# Altium schematic sheet Driver_BLDC.SchDoc
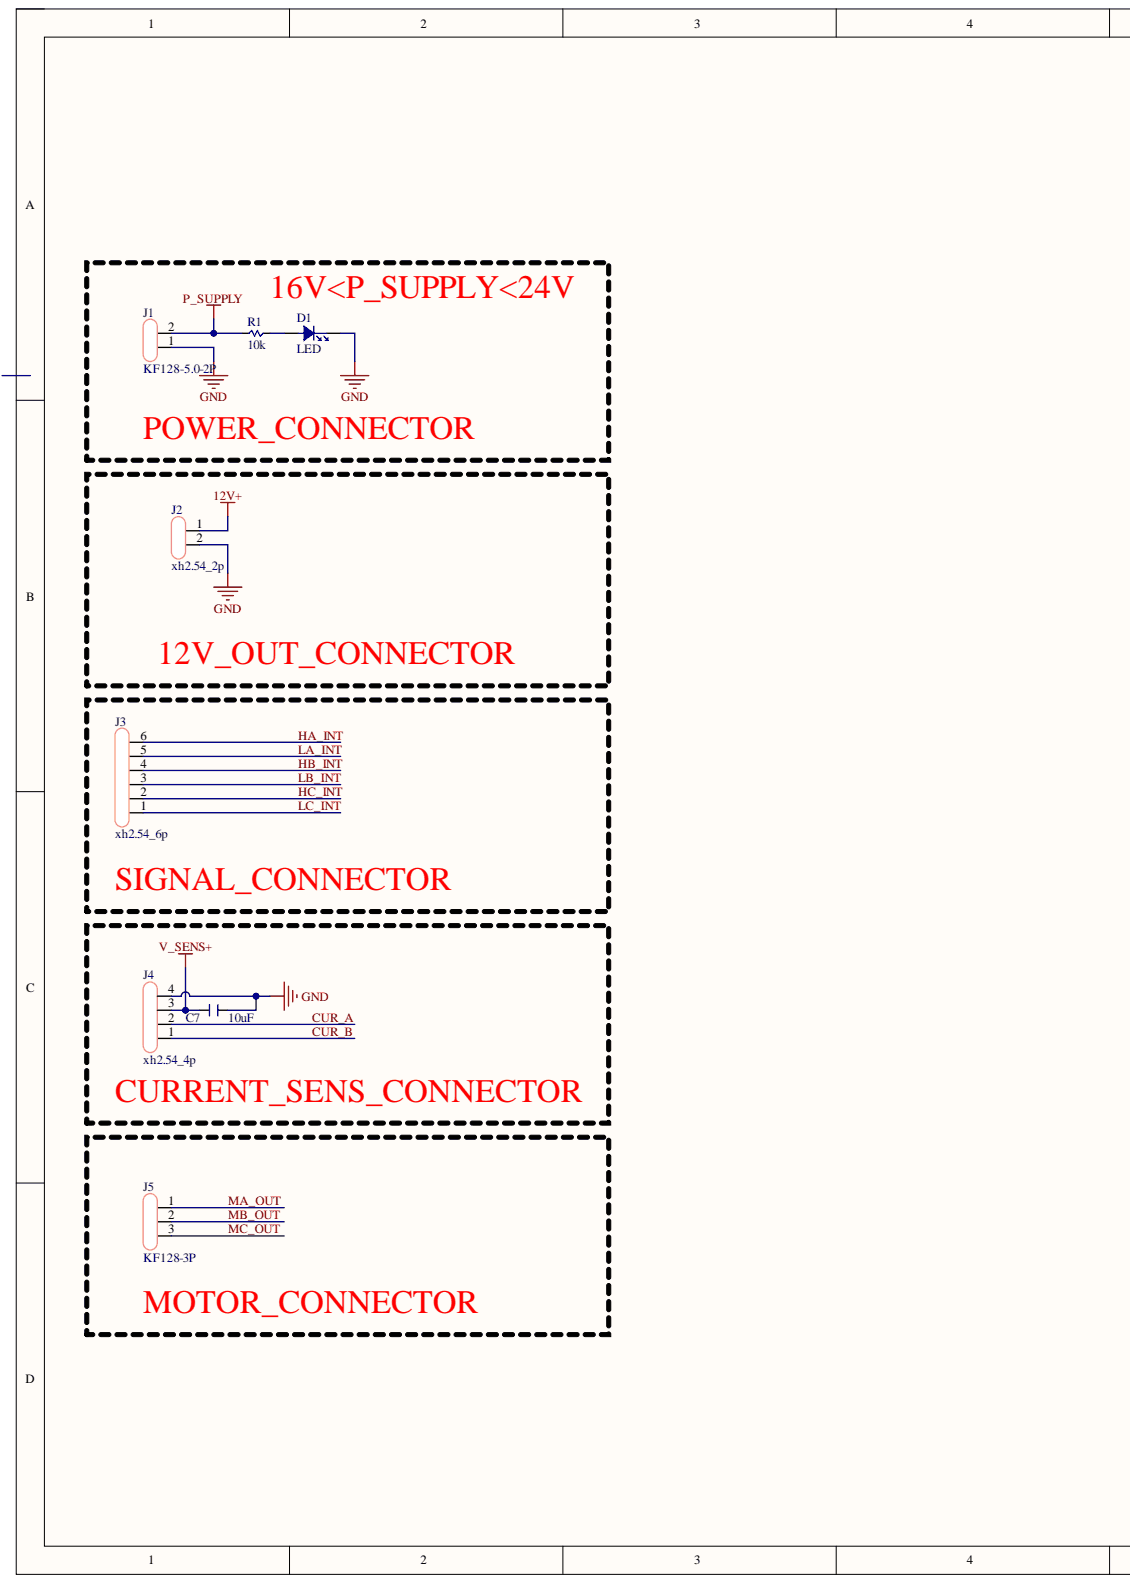
[diagram p0: Driver_BLDC.SchDoc, left]
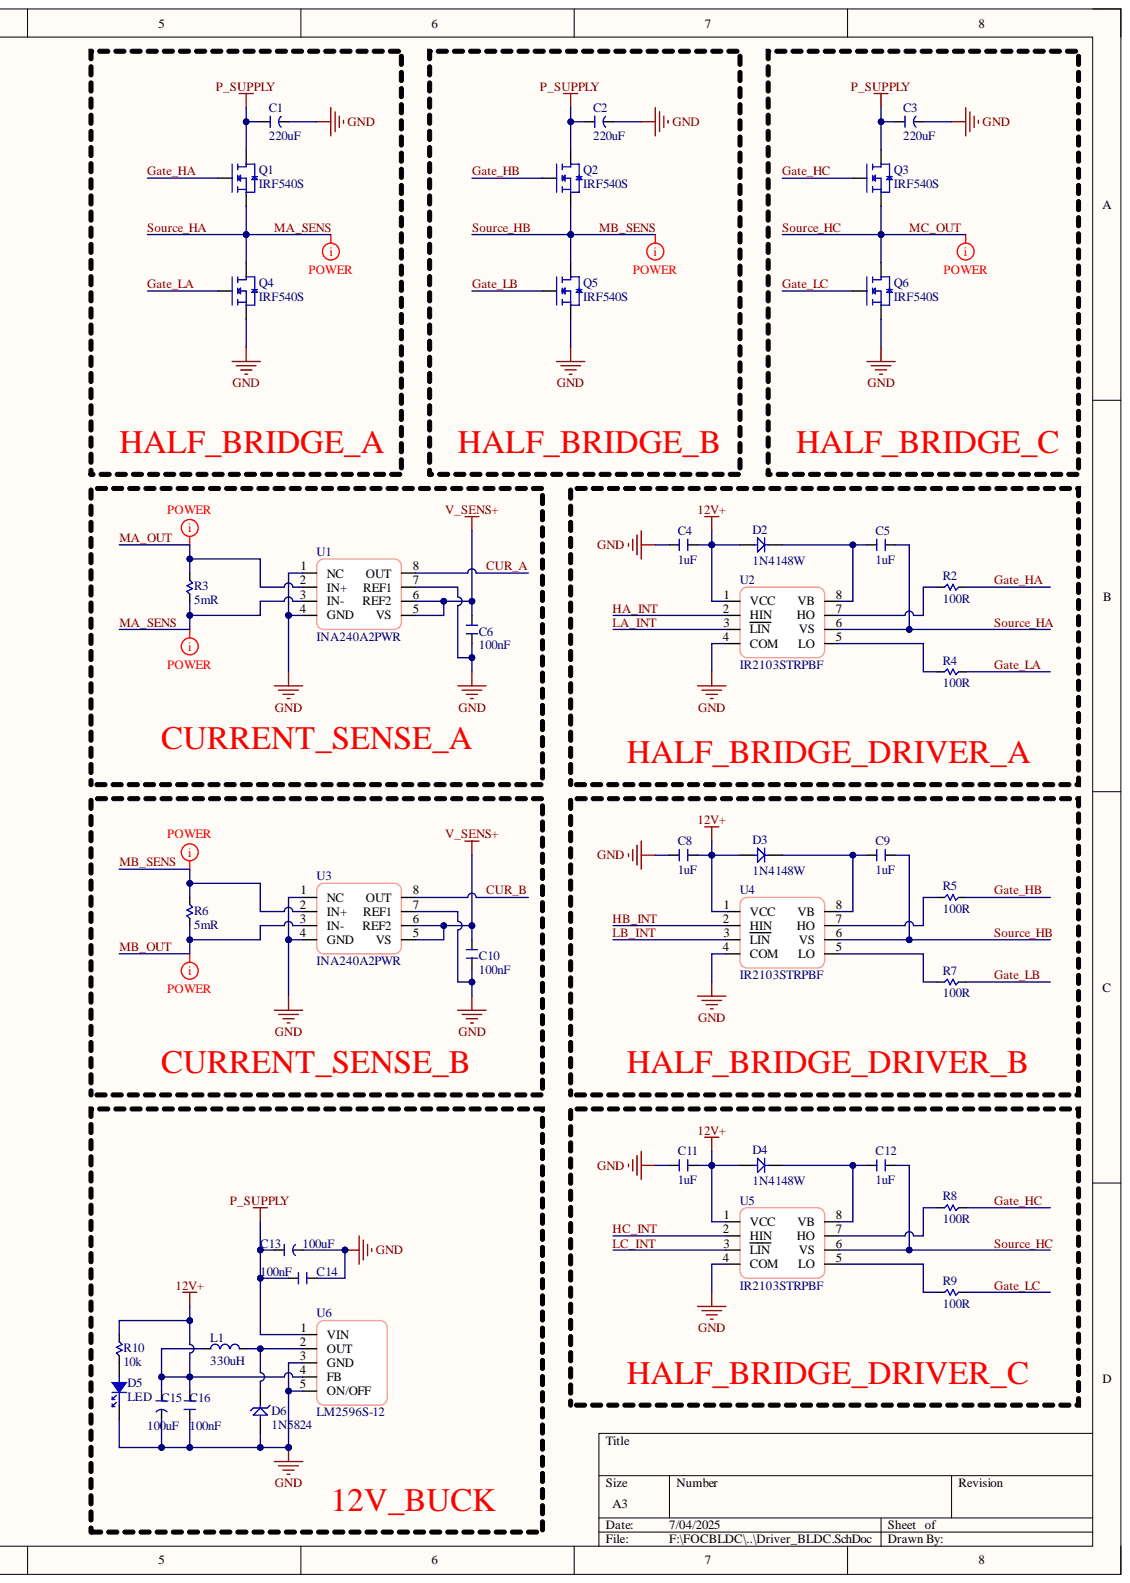
[diagram p1: Driver_BLDC.SchDoc, right]

## Parts
C1  220uF  [CAPAE9583X105M]
C2  220uF  [CAPAE9583X105M]
C3  220uF  [CAPAE9583X105M]
C4  1uF  [CAPC3216X18M]
C5  1uF  [CAPC3216X18M]
C6  100nF  [CAPC3216X18M]
C7  10uF  [CAPC3216X18M]
C8  1uF  [CAPC3216X18M]
C9  1uF  [CAPC3216X18M]
C10  100nF  [CAPC3216X18M]
C11  1uF  [CAPC3216X18M]
C12  1uF  [CAPC3216X18M]
C13  100uF  [CAPAE7466X77M]
C14  100nF  [CAPC3216X18M]
C15  100uF  [CAPAE7466X77M]
C16  100nF  [CAPC3216X18M]
D1  LED  [LEDC3216X120N]
D2  1N4148W  [SODFL370X135-2M]
D3  1N4148W  [SODFL370X135-2M]
D4  1N4148W  [SODFL370X135-2M]
D5  LED  [LEDC3216X120N]
D6  1N5824  [DIOM5025X22M]
J1  KF128-5.0-2P  [KF128-2P]
J2  xh2.54_2p  [xh2.54_2p]
J3  xh2.54_6p  [xh2.54_6p]
J4  xh2.54_4p  [xh2.54_4P]
J5  KF128-3P  [KF128-3P]
L1  330uH  [INDP120120X80M]
Q1  IRF540S  [DPAK254P1524X483-3M]
Q2  IRF540S  [DPAK254P1524X483-3M]
Q3  IRF540S  [DPAK254P1524X483-3M]
Q4  IRF540S  [DPAK254P1524X483-3M]
Q5  IRF540S  [DPAK254P1524X483-3M]
Q6  IRF540S  [DPAK254P1524X483-3M]
R1  10k  [RESC3116X06M]
R2  100R  [RESC3116X06M]
R3  5mR  [RESC6432X07M]
R4  100R  [RESC3116X06M]
R5  100R  [RESC3116X06M]
R6  5mR  [RESC6432X07M]
R7  100R  [RESC3116X06M]
R8  100R  [RESC3116X06M]
R9  100R  [RESC3116X06M]
R10  10k  [RESC3116X06M]
U1  INA240A2PWR  [TSOP65P640X120-8M]
U2  IR2103STRPBF  [SOIC127P600X175-8M]
U3  INA240A2PWR  [TSOP65P640X120-8M]
U4  IR2103STRPBF  [SOIC127P600X175-8M]
U5  IR2103STRPBF  [SOIC127P600X175-8M]
U6  LM2596S-12  [DPAK170P1435X470-6M]

## Nets
12V+: C4.1 C8.1 C11.1 C15.P C16.1 D2.A D3.A D4.A J2.1 L1.1 R10.1 U2.1(VCC) U4.1(VCC) U5.1(VCC) U6.4(FB)
GND: C1.N C2.N C3.N C4.2 C6.1 C7.2 C8.2 C10.1 C11.2 C13.N C14.2 C15.N C16.2 D1.K D5.K D6.A J1.1 J2.2 J4.4 Q4.S Q5.S Q6.S U1.1(NC) U1.4(GND) U1.7(REF1) U2.4(COM) U3.1(NC) U3.4(GND) U3.7(REF1) U4.4(COM) U5.4(COM) U6.3(GND) U6.5(ON/OFF)
P_SUPPLY: C1.P C2.P C3.P C13.P C14.1 J1.2 Q1.D Q2.D Q3.D R1.1 U6.1(VIN)
V_SENS+: C6.2 C7.1 C10.2 J4.3 U1.5(VS) U1.6(REF2) U3.5(VS) U3.6(REF2)
CUR_A: J4.2 U1.8(OUT)
CUR_B: J4.1 U3.8(OUT)
Gate_HA: Q1.G R2.2
Gate_HB: Q2.G R5.2
Gate_HC: Q3.G R8.2
Gate_LA: Q4.G R4.2
Gate_LB: Q5.G R7.2
Gate_LC: Q6.G R9.2
HA_INT: J3.6 U2.2(HIN)
HB_INT: J3.4 U4.2(HIN)
HC_INT: J3.2 U5.2(HIN)
LA_INT: J3.5 U2.3(LIN)
LB_INT: J3.3 U4.3(LIN)
LC_INT: J3.1 U5.3(LIN)
MA_OUT: J5.1 R3.2 U1.2(IN+)
MA_SENS: C5.1 Q1.S Q4.D R3.1 U1.3(IN-) U2.6(VS)
MB_OUT: J5.2 R6.1 U3.3(IN-)
MB_SENS: C9.1 Q2.S Q5.D R6.2 U3.2(IN+) U4.6(VS)
MC_OUT: C12.1 J5.3 Q3.S Q6.D U5.6(VS)
NetC5_2: C5.2 D2.K U2.8(VB)
NetC9_2: C9.2 D3.K U4.8(VB)
NetC12_2: C12.2 D4.K U5.8(VB)
NetD1_A: D1.A R1.2
NetD5_A: D5.A R10.2
NetD6_K: D6.K L1.2 U6.2(OUT)
NetR2_1: R2.1 U2.7(HO)
NetR4_1: R4.1 U2.5(LO)
NetR5_1: R5.1 U4.7(HO)
NetR7_1: R7.1 U4.5(LO)
NetR8_1: R8.1 U5.7(HO)
NetR9_1: R9.1 U5.5(LO)

## Library data of the parts
C1-C3 = VT1V221M-CRF10: 220uF 35V ±20% SMD,D8xL10.5mm Aluminum Electrolytic Capacitors - SMD ROHS; Component Kind: Standard; LCSC Part: C305376; Library Name: Driver_BLDC.SchLib
C4 C5 C8 C9 C11 C12 = CC1206JKX7R9BB105: 50V 1uF X7R ±5% 1206 Multilayer Ceramic Capacitors MLCC - SMD/SMT ROHS; Component Kind: Standard; LCSC Part: C527273; Library Name: Driver_BLDC.SchLib
C6 C10 C14 C16 = CC1206JRX7R9BB104: 50V 100nF X7R ±5% 1206 Multilayer Ceramic Capacitors MLCC - SMD/SMT ROHS; Component Kind: Standard; LCSC Part: C541547; Library Name: Driver_BLDC.SchLib
C7 = CC1206KKX7R8BB106: 25V 10uF X7R ±10% 1206 Multilayer Ceramic Capacitors MLCC - SMD/SMT ROHS; Component Kind: Standard; LCSC Part: C70462; Library Name: Driver_BLDC.SchLib
C13 C15 = VT1V101M-CRE77: 100uF 35V ±20% SMD,D6.3xL7.7mm Aluminum Electrolytic Capacitors - SMD ROHS; Component Kind: Standard; LCSC Part: C294520; Library Name: Driver_BLDC.SchLib
D1 D5 = LED_1206: SMD LED 1206; Available: no; Component Kind: Standard; Library Name: Driver_BLDC.SchLib
D2-D4 = 1N4148W: 75V Independent Type 1.25V@150mA 8ns 150mA SOD-123 Switching Diodes ROHS; C908248: C908248; Component Kind: Standard; Library Name: Driver_BLDC.SchLib
D6 = SS54: 40V Independent Type 550mV@5A 5A SMA(DO-214AC) Schottky Diodes ROHS; Component Kind: Standard; LCSC Part: C727065; Library Name: Driver_BLDC.SchLib
J1 = KF128-5.0-2P: 1x2P 24A -40℃~+105℃ 250V Green 12~22 Straight 5mm 2.5 1 2 Plugin,P=5mm Screw Terminal Blocks ROHS; Component Kind: Standard; LCSC Part: C474950; Library Name: Driver_BLDC.SchLib
J5 = KF128-5.0-3P: 1x3P 24A -40℃~+105℃ 250V Green 12~22 Straight 5mm 2.5 1 3 Plugin,P=5mm Screw Terminal Blocks ROHS; Component Kind: Standard; LCSC Part: C474951; Library Name: Driver_BLDC.SchLib
L1 = CDRH127/LDNP-331MC: 1.2A 330uH ±20% SMD-4P,12x12mm Power Inductors ROHS; Component Kind: Standard; LCSC Part: C2453076; Library Name: Driver_BLDC.SchLib
Q1-Q6 = IRF540S: Power Field-Effect Transistor, 28A I(D), 100V, 0.077ohm, 1-Element, N-Channel, Silicon, Metal-oxide Semiconductor FET, TO-263AB; Manufacturer: Vishay Siliconix; Avalanche Energy Rating (Eas): 230mJ; Case Connection: DRAIN; Drain-source On Resistance-Max: 77mR; Number of Elements: 1; Operating Mode: ENHANCEMENT MODE; Package Body Material: Plastic; Power Dissipation-Max (Abs): 150W; Pulsed Drain Current-Max (IDM): 110A; Qualification Status: Not Qualified; Transistor Application: SWITCHING; Category: Transistors; Component Kind: Standard; ComponentLink1Description: https://datasheet.ciiva.com:443/pdfs/VipMasterIC/IC/SILX/SILXS13402/SILXS13402-1.pdf?src-supplier=IHS+Markit; ComponentLink1URL: https://datasheet.ciiva.com:443/pdfs/VipMasterIC/IC/SILX/SILXS13402/SILXS13402-1.pdf?src-supplier=IHS+Markit; ComponentLink2Description: https://datasheet.ciiva.com/13048/sihf540s-60587-13048065.pdf?src-supplier=Mouser; ComponentLink2URL: https://datasheet.ciiva.com/13048/sihf540s-60587-13048065.pdf?src-supplier=Mouser; ComponentLink3Description: https://datasheet.ciiva.com/952/sihf540s-952269.pdf?src-supplier=Digikey; ComponentLink3URL: https://datasheet.ciiva.com/952/sihf540s-952269.pdf?src-supplier=Digikey; Drain Current-Max (Abs) (ID): 28A; Drain Current-Max (ID): 28A; DS Breakdown Voltage-Min: 100V; FET Technology: METAL-OXIDE SEMICONDUCTOR; JEDEC-95 Code: TO-263AB; JESD-30 Code: R-PSSO-G2; JESD-609 Code: e0; LCSC Part: C3025611; Library Name: Driver_BLDC.SchLib; Manufacturer Part Number: IRF540S; Max Operating Temperature: 175°C; Moisture Sensitivity Level: 1; Package Shape: Rectangular; Package Style: SMALL OUTLINEMeter; Pins: 2; Polarity/Channel Type: N-CHANNEL; RoHS: No; RoHS Compliant: No; Supplier: Avnet; Supplier Part Number: IRF540S; Surface Mount: Yes; Terminal Finish: Tin; Terminal Form: Gull Wing; Terminal Position: SINGLE; Transistor Element Material: SILICON
R1 R10 = RC1206FR-0710KL: 250mW Thick Film Resistors 200V ±100ppm/℃ ±1% 10kΩ 1206 Chip Resistor - Surface Mount ROHS; Component Kind: Standard; LCSC Part: C132649; Library Name: Driver_BLDC.SchLib
R2 R4 R5 R7-R9 = RC1206FR-07100RL: 250mW Thick Film Resistors 200V ±100ppm/℃ ±1% 100Ω 1206 Chip Resistor - Surface Mount ROHS; Component Kind: Standard; LCSC Part: C137392; Library Name: Driver_BLDC.SchLib
R3 R6 = WSL2512L5000FEA18: 2W 0.5mΩ Patch Current Sensing Resistors ±400ppm/℃ ±1% 2512 Current Sense Resistors / Shunt Resistors ROHS; Component Kind: Standard; LCSC Part: C1518119; Library Name: Driver_BLDC.SchLib
U1 U3 = INA240A2PWR: -4V~80V 400kHz Single channel TSSOP-8 Current Sense Amplifiers ROHS; Component Kind: Standard; LCSC Part: C129949; Library Name: Driver_BLDC.SchLib
U2 U4 U5 = IR2103STRPBF: Half Bridge 2 130mA 100ns 50ns 10V~20V 270mA SOIC-8 Gate Drivers ROHS; Component Kind: Standard; LCSC Part: C2957; Library Name: Driver_BLDC.SchLib
U6 = LM2596S-12: Step-down type Fixed 12V 4.5V~40V 3A TO-263-5L DC-DC Converters ROHS; Component Kind: Standard; LCSC Part: C19631824; Library Name: Driver_BLDC.SchLib
J2 = xh2.54_2p: Component Kind: Standard; Description: xh2.54_2p; Library Name: Driver_BLDC.SchLib
J3 = xh2.54_6p: Component Kind: Standard; Description: xh2.54_6p; Library Name: Driver_BLDC.SchLib
J4 = xh2.54_4p: Component Kind: Standard; Description: xh2.54_4p; Library Name: Driver_BLDC.SchLib
